# Altium schematic sheet Reset Bootloader and Mounting.SchDoc
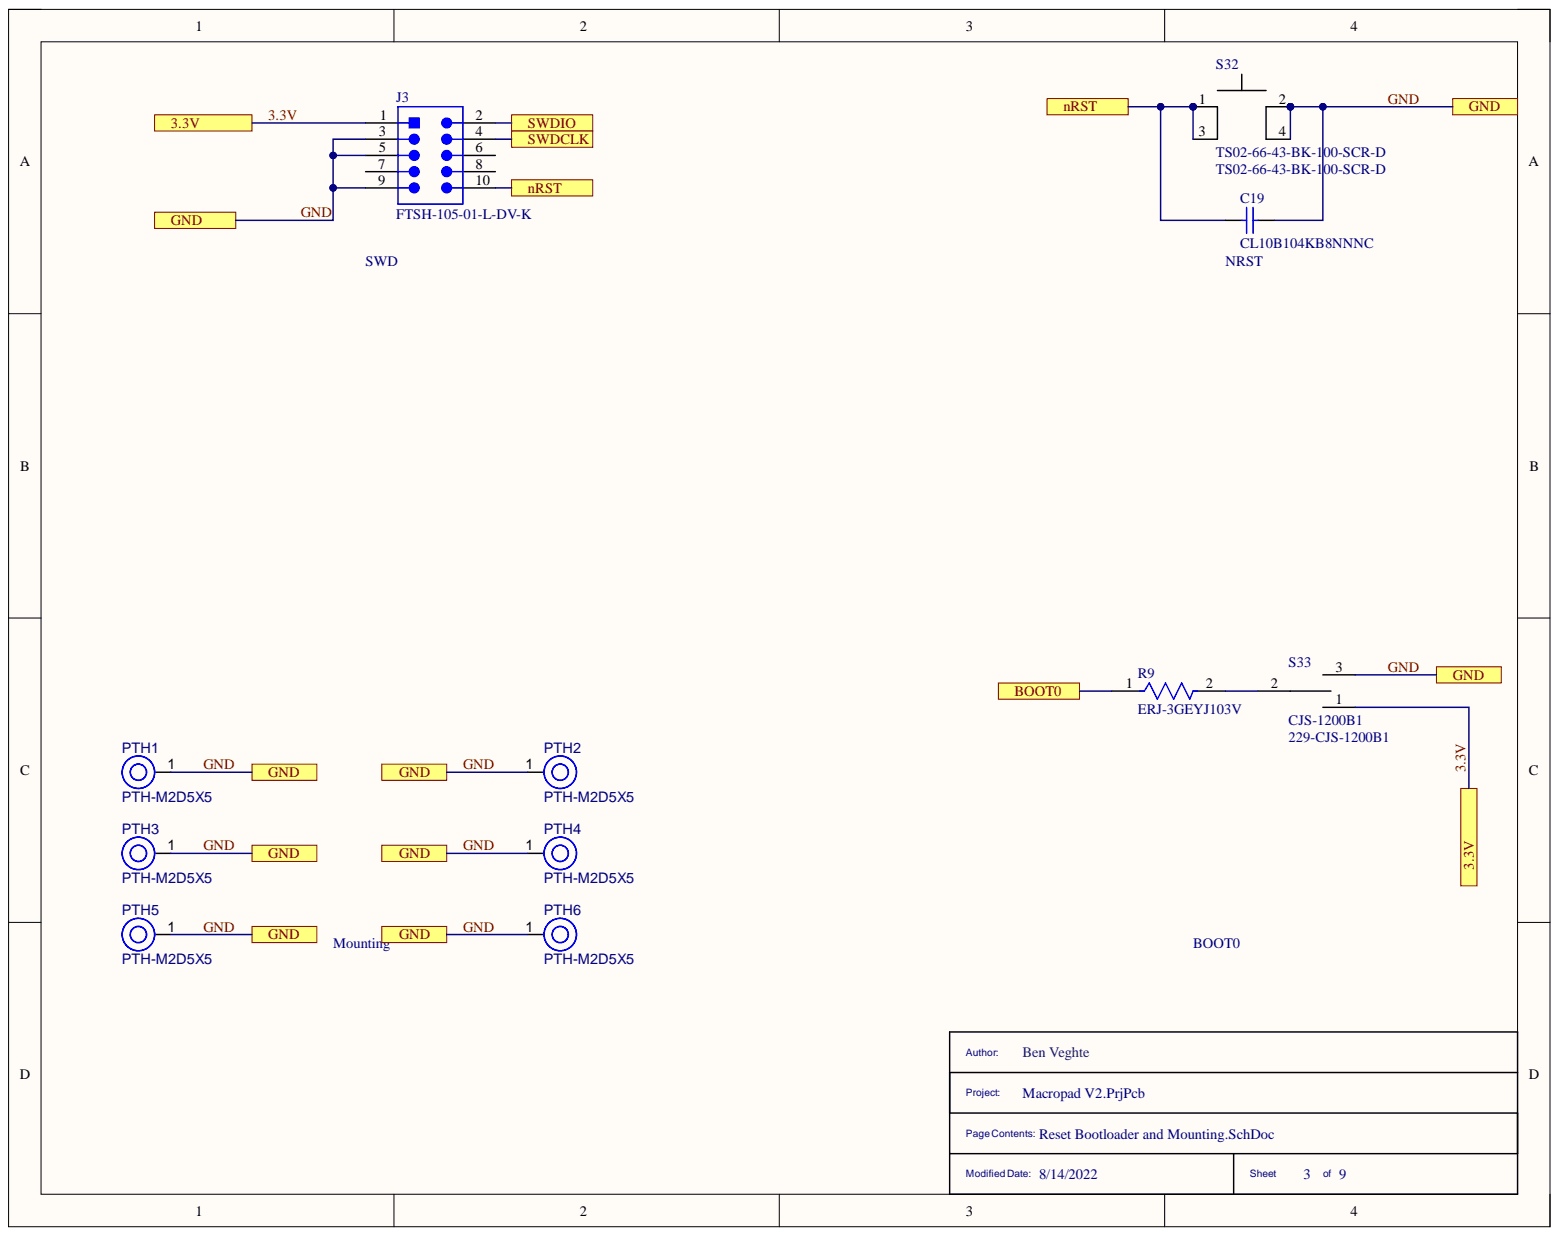
[diagram p0: Reset Bootloader and Mounting.SchDoc]

## Parts
C19  CL10B104KB8NNNC  [SAMS-C0603-2_M]
J3  FTSH-105-01-L-DV-K  [SMTC-FTSH-105-01-L-DV-K_V]
PTH1  PTH-M2D5X5  [PTH-M2D5X5]
PTH2  PTH-M2D5X5  [PTH-M2D5X5]
PTH3  PTH-M2D5X5  [PTH-M2D5X5]
PTH4  PTH-M2D5X5  [PTH-M2D5X5]
PTH5  PTH-M2D5X5  [PTH-M2D5X5]
PTH6  PTH-M2D5X5  [PTH-M2D5X5]
R9  ERJ-3GEYJ103V  [FP-ERJ3G-IPC_C]
S32  TS02-66-43-BK-100-SCR-D  [TS02-66-43-BK-100-SCR-D]
S33  CJS-1200B1  Supplier Part Number 2: 229-CJS-1200B1  [CJS-1200B1]

## Ports
3.3V, BOOT0, GND, nRST, SWDCLK, SWDIO

## Nets
3.3V: J3.1 S33.1 ; port 3.3V
BOOT0: R9.1 ; port BOOT0
GND: C19.2 J3.3 J3.5 J3.9 PTH1.1 PTH2.1 PTH3.1 PTH4.1 PTH5.1 PTH6.1 S32.2 S32.4 S33.3 ; port GND
nRST: C19.1 J3.10 S32.1 S32.3 ; port nRST
SWDCLK: J3.4 ; port SWDCLK
SWDIO: J3.2 ; port SWDIO
NetR9_2: R9.2 S33.2

Not connected: J3 6 7 8

## Library data of the parts
C19 = CMP-2000-05332-1: Multi-layer Ceramic Capacitor, -55 to 125 degC, 2-Pin SMD (0603), RoHS, Tape and Reel; Manufacturer: Samsung Electro-Mechanics; Capacitance: 0.1µF; Dielectric: X7R; Rated Voltage: 50V; Tolerance (%): ±10%; Case Size/Dimension: 0603; Component Kind: Standard; Datasheet URL: https://datasheet.ciiva.com/29198/456651-da-01-en-vs-kondensator-0605-29198839.pdf; Datasheet Version: Nov-10; Library Name: Altium Content Vault; Manufacturer URL: http://www.samsungsem.com/global/index.jsp
J3 = CMP-2000-05353-1: Male Header, Pitch 1.27 mm, 2 x 5 Position, Height 6.25 mm; Manufacturer: Samtec; Connector Type: Header,Unshrouded; Mating Products: SFMC-105-L2-S-D-TR-ND  -CONN.05"SURFACE10POSSMT  FLE-105-01-G-DV-TR-ND  -CONNSKT1.27MM10POSDUALSMD  FLE-105-01-G-DV-A-P-ND  -CONNSKT1.27MM10POSDUALSMD  FLE-105-01-G-DV-A-ND  -CONNSKT1.27MM10POSDUALSMD  CLP-105-02-G-D-P-TR-ND  -CONNRCPT10POSDUAL.05"SMD  SAM1155-05-ND  -CONNRCPT10POSDUAL.05"SMD  SAM1154-05-ND  -CONNRCPT10POSDUAL.05"SMD  SAM1153-05-ND  -CONNRCPT10POSDUAL.05"SMD  SAM1226-05-ND  -CONNRCPT.05"10POSDUALSMD; Number of Positions: 10; Number of Positions Loaded: All; Other Names: Q3937619; Pitch: 0.050"(1.27mm); Product Training Modules: Board-to-BoardConnectors; Category: Connectors,Interconnects; Color: Black; Component Kind: Standard; Contact Finish: Gold; Contact Finish Thickness: 10µin(0.25µm); Contact Mating Length: 0.120"(3.05mm); Contact Type: MalePin; Datasheet URL: http://suddendocs.samtec.com/prints/ftsh-1xx-xx-xxx-dv-xxx-mkt.pdf; Datasheet Version: Rev. ET, 10/1995; Family: RectangularConnectors-Headers,MalePins; Library Name: Altium Content Vault; Manufacturer URL: http://www.samtec.com/; Mounting Type: SurfaceMount; Number of Rows: 2; Package Description: Male Header, Pitch 1.27 mm, 2 x 5 Position, Height 5.97 mm, Tail Length 4.32 mm; Package Reference: FTSH-105-01-L-DV-K; Package URL: http://suddendocs.samtec.com/prints/ftsh-1xx-xx-xxx-dv-xxx-footprint.pdf; Packaging: Tube; Row Spacing: 0.050"(1.27mm); Series: FTSH; Standard Package: 1; Termination: Solder
PTH1-PTH6 = CMP-00028-00004-2: M2 PTH (2.5mm), 5mm pad; Component Kind: Standard; Pins: 1; Library Name: AerospaceNU
R9 = CMP-2000-00704-2: RES SMD 10K OHM 5% 1/10W 0603; Max Power Dissipation: 100mW; Resistance: 10kR; Temperature Coefficient: 200ppm/°C; Voltage Rating: 75V; Voltage Rating (DC): 50V; Case Code (Imperial): 0603; Case Code (Metric): 1608; Case/Package: 0603; Component Kind: Standard; Construction: Rectangular; Contact Plating: Tin; Depth: 0.8mm; Features: Automotive AEC-Q200; Height: 0.45mm; Lead Free: Lead Free; Length: 1.6mm; Library Name: Altium Content Vault; Max Operating Temperature: 155°C; Military Standard: Not; Min Operating Temperature: -55°C; Mount: Surface Mount; Number of Terminations: 2; Package Quantity: 1; Packaging: Tape and Reel; Power Rating: 100mW; REACH SVHC: No SVHC; Resistance Tolerance: 5%; Resistor Type: Thick Film; RoHS Compliant: Yes; Series: ERJ; Technology: THICK FILM; Termination: SMD/SMT; Tolerance: 5%; Weight: 7.1E-05oz; Width: 0.8mm; Working Voltage: 75V
S32 = TS02-66-43-BK-100-SCR-D: Switch Tactile OFF (ON) SPST Round Button PC Pins 0.05A 12VDC 100000Cycles 1N Thru-Hole Bag; Manufacturer: CUI Devices; Component Kind: Standard; Manufacturer Part Number: TS02-66-43-BK-100-SCR-D; Pricing 1: 1=0.09100, 25=0.08560, 100=0.07670, 250=0.07150, 500=0.06460, 1000=0.05270, 2000=0.05130, 5000=0.04740 (USD); RoHS: No; Stock 1: 6000; Supplier 1: Arrow Electronics; Supplier Part Number 1: TS02-66-43-BK-100-SCR-D; Library Name: Universal Parts.IntLib
S33 = CJS-1200B1: Switch Slide N.O./N.C. SPDT Raised Slide 0.1A 24VDC Gull Wing SMD Bulk; Manufacturer: CUI; Manufacturer Part Number: DS01-254-L-01BE; Max Voltage Rating (DC): 24V; Case/Package: SMD/SMT; Category: DIP Switches; Component Kind: Standard; ComponentLink1Description: https://www.nidec-copal-electronics.com/e/catalog/switch/cjs.pdf?src-supplier=Digi-Key; ComponentLink1URL: https://www.nidec-copal-electronics.com/e/catalog/switch/cjs.pdf?src-supplier=Digi-Key; ComponentLink2Description: http://www.nidec-copal-electronics.com/e/product/files/file/b1d651d581b7f6382a1a6c96f0c036e447720c59.pdf?src-supplier=Avnet; ComponentLink2URL: http://www.nidec-copal-electronics.com/e/product/files/file/b1d651d581b7f6382a1a6c96f0c036e447720c59.pdf?src-supplier=Avnet; Contact Material: Copper Alloy; Contact Plating: Gold; Lead Free: Lead Free; Max Operating Temperature: 85°C; Min Operating Temperature: -40°C; Mount: Surface Mount; Packaging: Bulk; Pricing 2: 1=0.73000, 10=0.64700, 20=0.63300, 50=0.63300, 100=0.63200, 200=0.56100, 500=0.53500, 1000=0.51700, 2000=0.49800, 10000=0.49800 (USD); Radiation Hardening: No; RoHS: Yes; RoHS Compliant: Yes; Stock 2: 600; Supplier 1: Mouser; Supplier 2: Mouser; Termination: Gull Wing; Throw Configuration: SPDT; Library Name: Universal Parts.IntLib
